# Altium schematic sheet Sheet_Mcu_v1.SchDoc(Sheet_Mcu_v1)
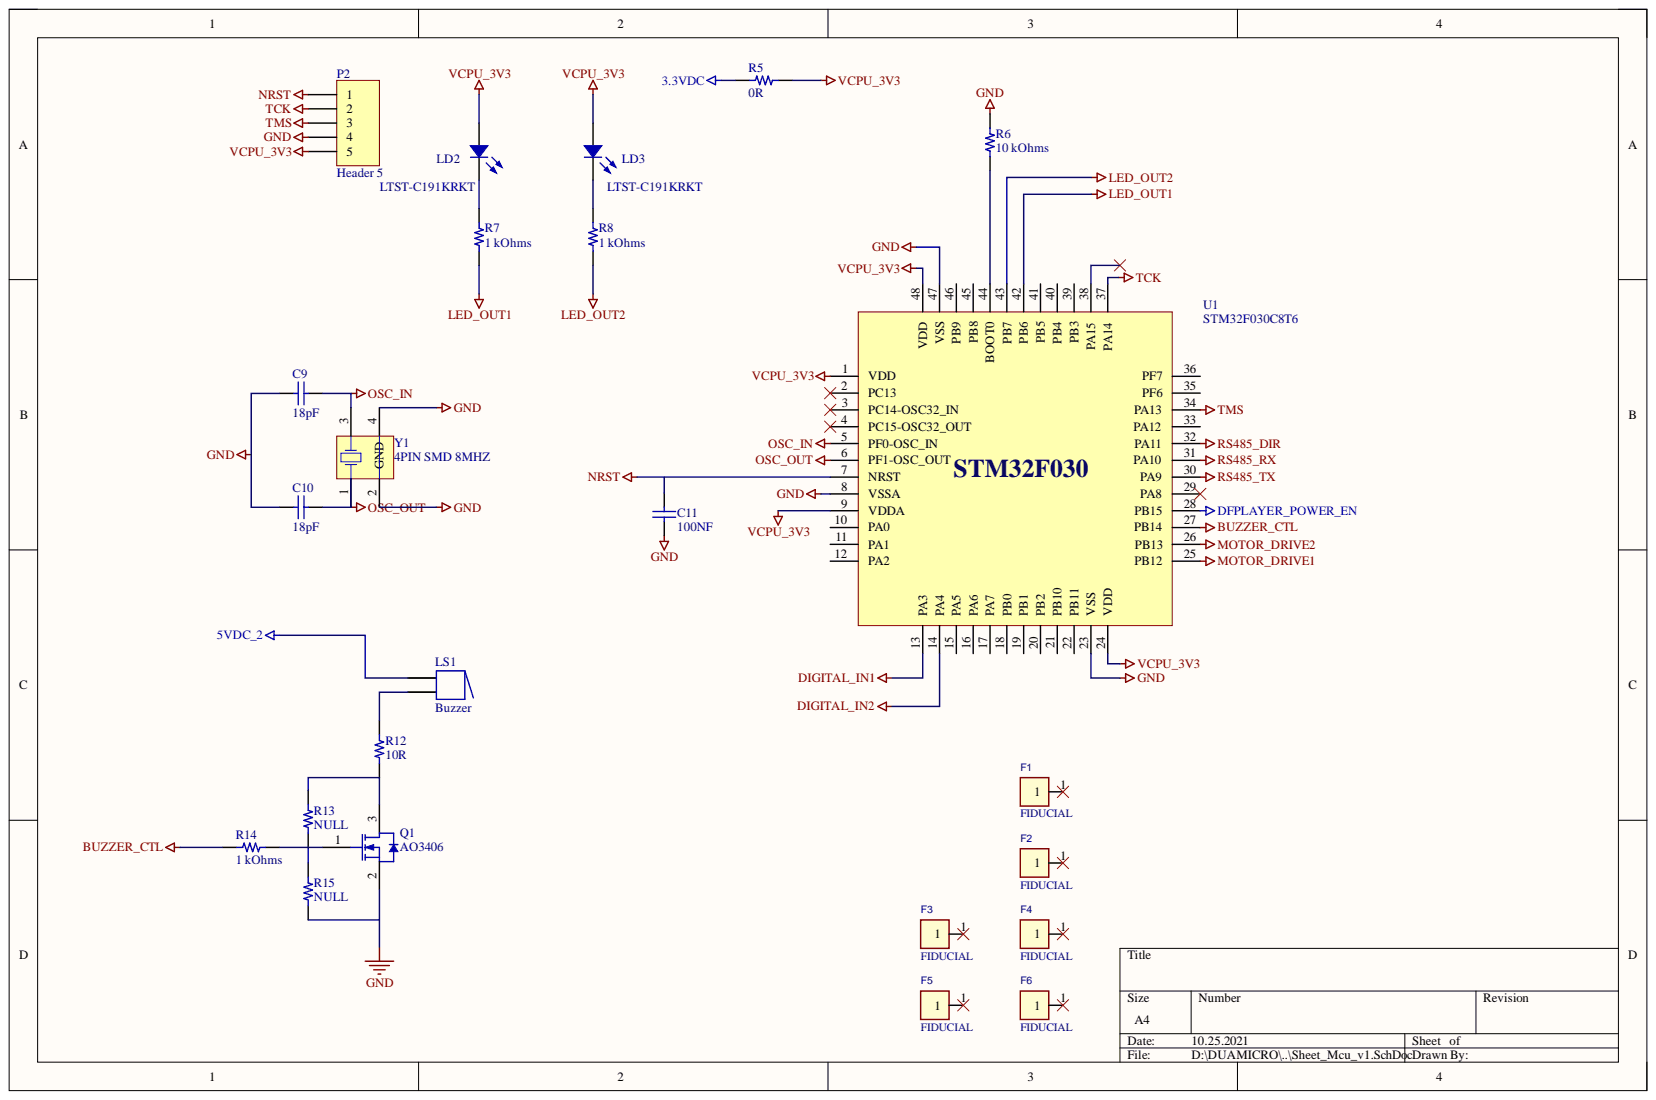
[diagram p0: Sheet_Mcu_v1.SchDoc]

## Parts
C9  18pF  [CAP 0603_1608]
C10  18pF  [CAP 0603_1608]
C11  100NF  [CAP 0603_1608]
F1  FIDUCIAL  [M4HOLE]  (no BOM)
F2  FIDUCIAL  [M4HOLE]  (no BOM)
F3  FIDUCIAL  [SM/FID]  (no BOM)
F4  FIDUCIAL  [M4HOLE]  (no BOM)
F5  FIDUCIAL  [SM/FID]  (no BOM)
F6  FIDUCIAL  [M4HOLE]  (no BOM)
LD2  LTST-C191KRKT  [SMD LED_RED_603]
LD3  LTST-C191KRKT  [SMD LED_RED_603]
LS1  Buzzer  [ABSM-1574]
P2  Header 5  [HDR1X5]
Q1  AO3406  [ON SEMI SOT-23-3]
R5  0R  [RES 0603/1608]
R6  10 kOhms  [RES 0603/1608]
R7  1 kOhms  [RES 0603/1608]
R8  1 kOhms  [RES 0603/1608]
R12  10R  [RES 0603/1608]
R13  NULL  [RES 0603/1608]
R14  1 kOhms  [RES 0603/1608]
R15  NULL  [RES 0603/1608]
U1  STM32F030C8T6  [LQFP48]
Y1  4PIN SMD 8MHZ  [PCB - CRYSTALS - ABRACON ASVTX-09]

## Nets
3.3VDC: R5.1
5VDC_2: LS1.1 LS1.1A
BUZZER_CTL: R14.1 U1.27(PB14)
DFPLAYER_POWER_EN: U1.28(PB15)
DIGITAL_IN1: U1.13(PA3)
DIGITAL_IN2: U1.14(PA4)
GND: C9.2 C10.2 C11.1 P2.4 Q1.2 R6.2 R15.1 U1.8(VSSA) U1.23(VSS) U1.47(VSS) Y1.2 Y1.4(GND)
LED_OUT1: R7.1 U1.42(PB6)
LED_OUT2: R8.1 U1.43(PB7)
MOTOR_DRIVE1: U1.25(PB12)
MOTOR_DRIVE2: U1.26(PB13)
NRST: C11.2 P2.1 U1.7(NRST)
OSC_IN: C9.1 U1.5(PF0-OSC_IN) Y1.3
OSC_OUT: C10.1 U1.6(PF1-OSC_OUT) Y1.1
RS485_DIR: U1.32(PA11)
RS485_RX: U1.31(PA10)
RS485_TX: U1.30(PA9)
TCK: P2.2 U1.37(PA14)
TMS: P2.3 U1.34(PA13)
VCPU_3V3: LD2.1 LD3.1 P2.5 R5.2 U1.1(VDD) U1.9(VDDA) U1.24(VDD) U1.48(VDD)
NetLD2_2: LD2.2 R7.2
NetLD3_2: LD3.2 R8.2
NetLS1_2: LS1.2 LS1.2A R12.2
NetQ1_1: Q1.1 R13.1 R14.2 R15.2
NetQ1_3: Q1.3 R12.1 R13.2
NetR6_1: R6.1 U1.44(BOOT0)

Not connected: F1 1; F2 1; F3 1; F4 1; F5 1; F6 1; U1 2(PC13) 3(PC14-OSC32_IN) 4(PC15-OSC32_OUT) 10(PA0) 11(PA1) 12(PA2) 15(PA5) 16(PA6) 17(PA7) 18(PB0) 19(PB1) 20(PB2) 21(PB10) 22(PB11) 29(PA8) 33(PA12) 35(PF6) 36(PF7) 38(PA15) 39(PB3) 40(PB4) 41(PB5) 45(PB8) 46(PB9)

## Library data of the parts
C9 C10 = (no symbol name): CAP CER 18PF 10V NP0 0603; Manufacturer: KEMET; Part Number: C0603C180K8GAC7867; TemperatureRange: -55°C ~ 125°C; Tolerance: ±10%; Type: General Purpose; Component Kind: Standard; ComponentLink1Description: Datasheet; ComponentLink1URL: https://content.kemet.com/datasheets/KEM_C1003_C0G_SMD.pdf; ComponentLink2Description: Digi-Key listing; ComponentLink2URL: https://www.digikey.com/product-detail/en/kemet/C0603C180K8GAC7867/399-17399-1-ND/8570366; Device Package: 0603 (1608 Metric); Price: $0.32000; Supplier 1: Digi-Key; Supplier Part Number 1: 399-17399-1-ND; Value: 18pF; Voltage: 10V; Library Name: DualMicrolib.SchLib
C11 = CAP CER 100NF 16V X7R 0603: Manufacturer: Samsung Electro-Mechanics; Part Number: CL10B104KO8NNNC; TemperatureRange: -55°C ~ 125°C; Tolerance: ±10%; Type: General Purpose; Component Kind: Standard; ComponentLink1Description: Datasheet; ComponentLink1URL: http://www.samsungsem.com/kr/support/product-search/mlcc/__icsFiles/afieldfile/2016/08/18/S_CL10B104KO8NNNC.pdf; ComponentLink2Description: Digi-Key listing; ComponentLink2URL: https://www.digikey.com/product-detail/en/samsung-electro-mechanics/CL10B104KO8NNNC/1276-1005-1-ND/3889091; Description: CAP CER 100NF 16V X7R 0603; Device Package: 0603 (1608 Metric); Price: $0.10000; Supplier 1: Digi-Key; Supplier Part Number 1: 1276-1005-1-ND; Value: 100NF; Voltage: 16V; Library Name: DualMicrolib.SchLib
F1-F6 = CON1: Library Name: Schlib_DualMicro_rev1.0.0.SchLib
LD2 LD3 = SMD_603_LED_RED: Component Kind: Standard; Library Name: DualMicrolib.SchLib
LS1 = Buzzer: Magnetic Transducer Buzzer; Component Kind: Standard; ComponentLink1Description: Manufacturer Link; ComponentLink1URL: http://www.megastar.com/linecard/buzzmode/index.php; DatasheetVersion: 17-Dec-2003; LatestRevisionDate: 17-Dec-2003; LatestRevisionNote: Schematic revised, PCB Footprint replaced.; Library Name: Altium_Sch.SchLib; PackageDescription: Magnetic Transducer Buzzer; PackageReference: ABSM-1574; Published: 24-Mar-1999; Publisher: Altium Limited
P2 = Header 5: Header, 5-Pin; Component Kind: Standard; LatestRevisionDate: 17-Jul-2002; LatestRevisionNote: Re-released for DXP Platform.; Library Name: Altium_Sch.SchLib; Signal Integrity: Connector; Publisher: Altium Limited
Q1 = MOSFET N-HANEL: HOTTECH MOSFET N-CHANNEL AO3406 SOT-23; Manufacturer: Alpha & Omega Semiconductor; Current - Continuous Drain: 3.6A(Ta); Drain to Source Voltage: 30V; Drive Voltage: 4.5V,10V; Gate Charge: 5nC@10V; Power Dissipation: 1.4W; Vgs(Max): 20V; Vgs(th): 2.5V@250uA; Input Capacitance: 210pF@15V; Component Kind: Standard; ComponentLink1Description: Digi Key; ComponentLink1URL: www.digikey.be/product-detail/en/alpha-omega-semiconductor-inc/AO3406/785-1005-1-ND/1855947; ComponentLink2Description: Datasheet; ComponentLink2URL: https://media.digikey.com/pdf/Data%20Sheets/Alpha%20&%20Omega/AO3406.pdf; FET Type: N - Channel; Operating Temperature: -55`C~150`C; Part Number: AO3406; Supplier: Digi - Key; Supplier Device Package: SOT-23-3; Technology: MOSFET; Library Name: ESKA_INT_ALL.IntLib
R5 = Resistor_0R_0603: RES SMD 0 OHM JUMPER 1/10W 0603; Manufacturer: Panasonic Electronic Components; Part Number: ERJ-3GEY0R00V; PowerRating: 0.1W, 1/10W; TemperatureRange: -55C ~ 155C; Component Kind: Standard; ComponentLink1Description: Datasheet; ComponentLink1URL: https://industrial.panasonic.com/ww/products/resistors/chip-resistors/general-purpose-chip-resistors/thick-film-chip-resistors/ERJ3GEY0R00V; ComponentLink2Description: Digi-Key Listing; ComponentLink2URL: https://www.digikey.com/product-detail/en/panasonic-electronic-components/ERJ-3GEY0R00V/P0.0GCT-ND/134711; Device Package: 0603 (1608 Metric); Price: $0.10000/min1; Supplier 1: Digi-Key; Supplier Part Number 1: P0.0GCT-ND; TemperatureCoefficient: -; Tolerance: Jumper; Value: 0R; Library Name: DualMicrolib.SchLib
R6 = Resistor_10K_0603_5%: RES SMD 10K OHM 5% 1/16W 0603; Manufacturer: Stackpole Electronics; Part Number: RMCF0603JG10K0; PowerRating: 0.1W, 1/10W; TemperatureCoefficient: ±100ppm/°C; TemperatureRange: -55°C ~ 125°C; Component Kind: Standard; ComponentLink1Description: Datasheet; ComponentLink1URL: http://www.seielect.com/catalog/sei-packaging.pdf; ComponentLink2Description: Digi-Key Listing; ComponentLink2URL: https://www.digikey.com/en/products/detail/stackpole-electronics-inc/rmcf0603jg10k0/1712133; Device Package: 0603 (1608 Metric); Price: $0.1000/min1; Supplier 1: DigiKey; Supplier 2: Digi-Key; Supplier Part Number 1: RMCF0603JG10K0; Supplier Part Number 2: RMCF0603JG10K0CT-ND; Tolerance: ±5%; Value: 10 kOhms; Library Name: DualMicrolib.SchLib
R7 R8 R14 = Resistor_1K_0603_5%: RES SMD 1K OHM 5% 1/16W 0603; Manufacturer: Stackpole Electronics; Part Number: RMCF0603JG1K00; PowerRating: 0.1W, 1/10W; TemperatureCoefficient: ±100ppm/°C; TemperatureRange: -55°C ~ 125°C; Component Kind: Standard; ComponentLink1Description: Datasheet; ComponentLink1URL: http://www.seielect.com/catalog/sei-packaging.pdf; ComponentLink2Description: Digi-Key Listing; ComponentLink2URL: https://www.digikey.com/product-detail/en/stackpole-electronics-inc/RMCF0603FT100K/RMCF0603FT100KCT-ND/1943118; Device Package: 0603 (1608 Metric); Price: $0.1000/min1; Supplier 1: Digi-Key; Supplier Part Number 1: RMCF0603JG1K00; Tolerance: ±5%; Value: 1 kOhms; Library Name: DualMicrolib.SchLib
R12 = (no symbol name): CRGCQ 0603 10R 1%; Manufacturer: TE Connectivity Passive Product; Part Number: CRGCQ0603F10R; PowerRating: 0.1W, 1/10W; TemperatureCoefficient: ±400ppm/°C; TemperatureRange: -55°C ~ 155°C; Component Kind: Standard; ComponentLink1Description: Datasheet; ComponentLink1URL: https://www.te.com/commerce/DocumentDelivery/DDEController?Action=srchrtrv&DocNm=1773204-3&DocType=DS&DocLang=English; ComponentLink2Description: Digi-Key Listing; ComponentLink2URL: https://www.digikey.com/product-detail/en/te-connectivity-passive-product/CRGCQ0603J10R/A130079CT-ND/8577911; Device Package: 0603 (1608 Metric); Price: $0.10000/min1; Supplier 1: Digi-Key; Supplier Part Number 1: A129664TR-ND; Tolerance: ±1%; Value: 10R; Library Name: DualMicrolib.SchLib
R13 R15 = Resistor_NULL_0603: RES SMD NULL OHM JUMPER 1/10W 0603; Manufacturer: Panasonic Electronic Components; PowerRating: 0.1W, 1/10W; TemperatureRange: -55C ~ 155C; Component Kind: Standard; ComponentLink1Description: Datasheet; ComponentLink1URL: https://industrial.panasonic.com/ww/products/resistors/chip-resistors/general-purpose-chip-resistors/thick-film-chip-resistors/ERJ3GEY0R00V; ComponentLink2Description: Digi-Key Listing; ComponentLink2URL: https://www.digikey.com/product-detail/en/panasonic-electronic-components/ERJ-3GEY0R00V/P0.0GCT-ND/134711; Device Package: 0603 (1608 Metric); Price: $0.10000/min1; TemperatureCoefficient: -; Tolerance: Jumper; Value: NULL; Library Name: DualMicrolib.SchLib
U1 = STM32F030: Component Kind: Standard; Library Name: Schlib (ASSAN).SchLib
Y1 = CRYSTAL 8MHZ: H130B-8.000-18-3030-EXT-TR; Component Kind: Standard; Library Name: DualMicrolib.SchLib
